# Altium schematic sheet ESP32_CAN_V2-main.SchDoc
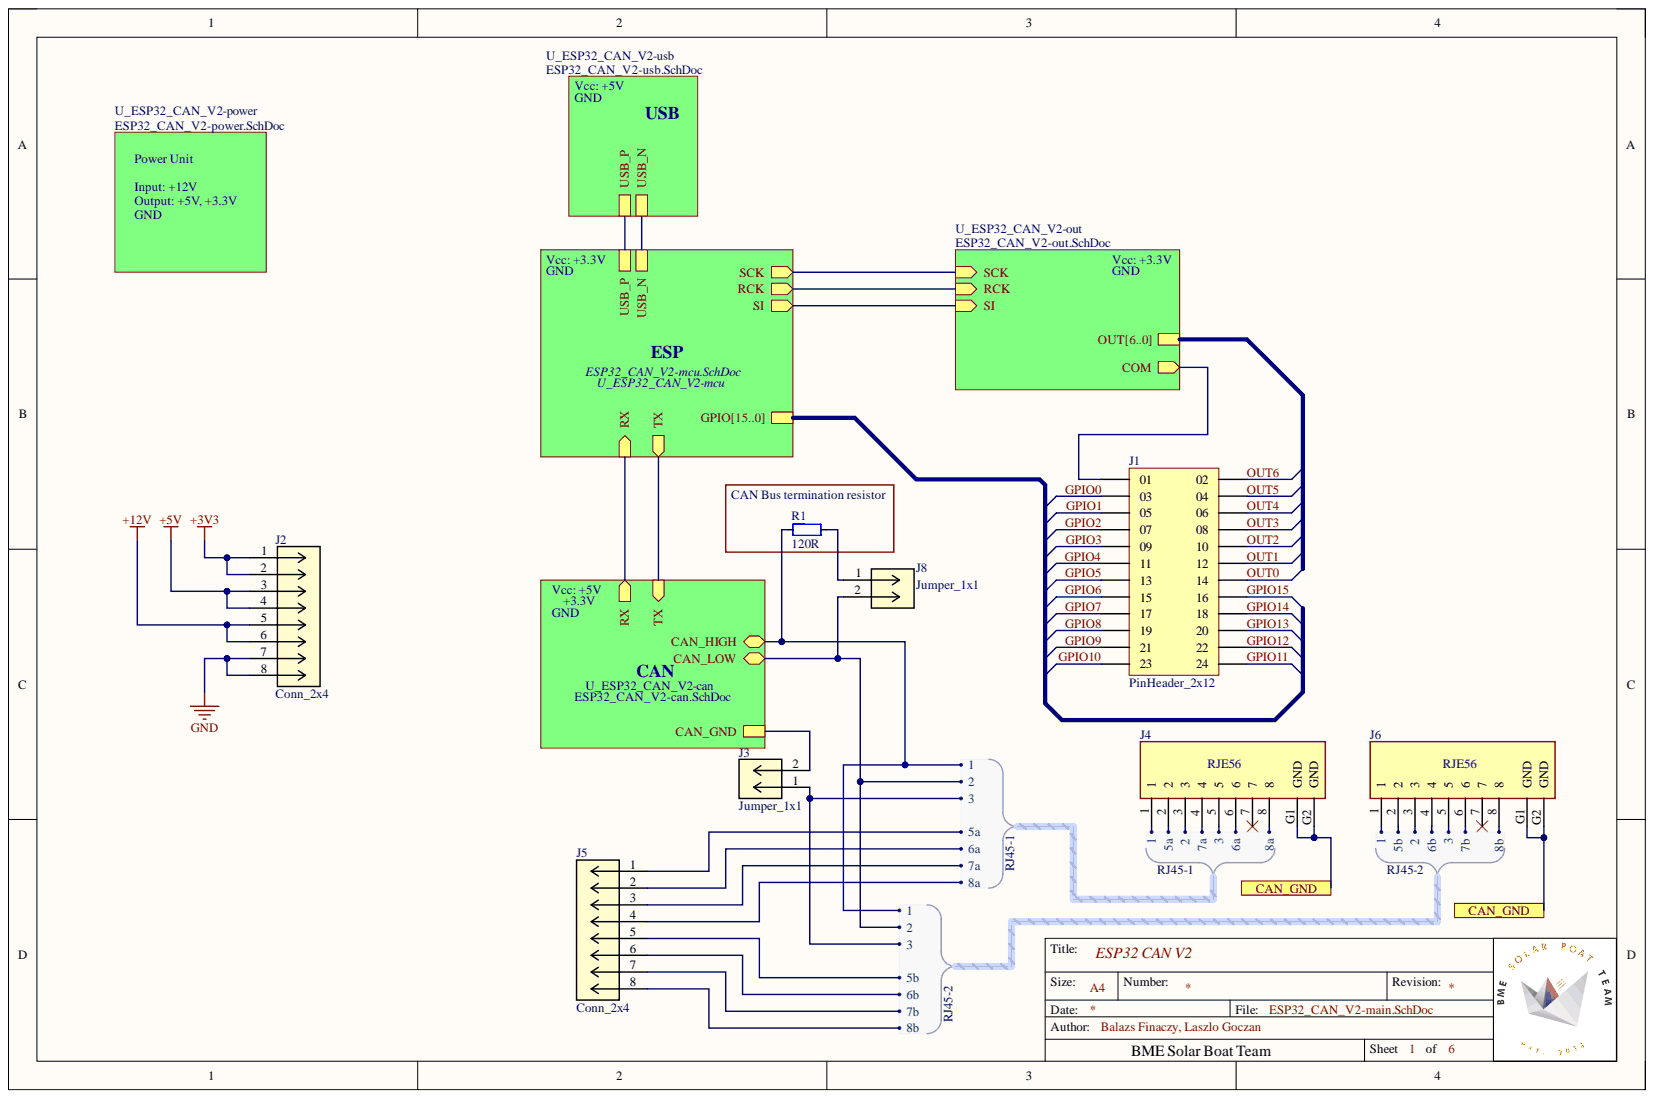
[diagram p0: ESP32_CAN_V2-main.SchDoc]

## Parts
J1  PinHeader_2x12  [AMPHENOL_10129381-924003BLF]
J2  Conn_2x4  [CONN_10129381-X08002XLF_AMP]
J3  Jumper_1x1  [CON2_1X2_TU_TSW]
J4  RJE56  [AMPHENOL_RJE561881410]
J5  Conn_2x4  [CONN_10129381-X08002XLF_AMP]
J6  RJE56  [AMPHENOL_RJE561881410]
J8  Jumper_1x1  [CON2_1X2_TU_TSW]
R1  120R  [R0603]

## Ports
CAN_GND

## Nets
+3V3: J2.1 J2.2
+5V: J2.3 J2.4
+12V: J2.5 J2.6
GND: J2.7 J2.8
CAN_GND: J4.G1(GND) J4.G2(GND) J6.G1(GND) J6.G2(GND) ; port CAN_GND
GPIO0: J1.03  [also labelled ]
GPIO1: J1.05  [also labelled ]
GPIO2: J1.07  [also labelled ]
GPIO3: J1.09  [also labelled ]
GPIO4: J1.11  [also labelled ]
GPIO5: J1.13  [also labelled ]
GPIO6: J1.15  [also labelled ]
GPIO7: J1.17  [also labelled ]
GPIO8: J1.19  [also labelled ]
GPIO9: J1.21  [also labelled ]
GPIO10: J1.23  [also labelled ]
GPIO11: J1.24  [also labelled ]
GPIO12: J1.22  [also labelled ]
GPIO13: J1.20  [also labelled ]
GPIO14: J1.18  [also labelled ]
GPIO15: J1.16  [also labelled ]
OUT0: J1.14  [also labelled ]
OUT1: J1.12  [also labelled ]
OUT2: J1.10  [also labelled ]
OUT3: J1.08  [also labelled ]
OUT4: J1.06  [also labelled ]
OUT5: J1.04  [also labelled ]
OUT6: J1.02  [also labelled ]
NetJ1_01: J1.01
NetJ3_1: J3.1 J4.5 J6.5
NetJ3_2: J3.2
NetJ4_1: J4.1 J6.1 R1.1
NetJ4_2: J4.2 J5.1
NetJ4_3: J4.3 J6.3 J8.2
NetJ4_4: J4.4 J5.3
NetJ4_6: J4.6 J5.2
NetJ4_7: J4.7
NetJ4_8: J4.8 J5.4
NetJ5_5: J5.5 J6.2
NetJ5_6: J5.6 J6.4
NetJ5_7: J5.7 J6.6
NetJ5_8: J5.8 J6.8
NetJ6_7: J6.7
NetJ8_1: J8.1 R1.2
RCK_1:
RX_1:
SCK_1:
SI_1:
TX_1:
USB_1_N:
USB_1_P:

## Library data of the parts
J1 = PinHeader_2x12: MF: {'manufacturer': u'Amphenol ICC (FCI)'}; MP: 10129381-924003BLF; Availability: Unavailable; Component Kind: Standard; Library Name: ESP32_CAN_V2.SchLib; Package: None; Price: None
J2 J5 = PinHeader_2x4: Manufacturer_Name: Amphenol ICC; Manufacturer_Part_Number: 10129381-908002BLF; Component Kind: Standard; Library Name: ESP32_CAN_V2.SchLib; RefDes: RefDes; Type: Type; Copyright: Copyright (C) 2026 Ultra Librarian. All rights reserved.
J3 J8 = TSW-102-08-T-S: No Description Available; Manufacturer_Name: Samtec Inc; Component Kind: Standard; Library Name: ESP32_CAN_V2.SchLib; Manufacturer_Part_Number: TSW-102-08-T-S; RefDes: RefDes; Type: Type; Copyright: Copyright (C) 2026 Ultra Librarian. All rights reserved.
J4 J6 = RJE561881410: Modular Jack - Right Angle, Input Output Connectors 8P8C, Without Shield .; MF: Amphenol Commercial Products; Reference: RJE56-188-1410; Check_prices: https://www.snapeda.com/parts/RJE561881410/Amphenol/view-part/?ref=eda; Component Kind: Standard; Library Name: ESP32_CAN_V2.SchLib; MP: RJE561881410; Package: None; SnapEDA_Link: https://www.snapeda.com/parts/RJE561881410/Amphenol/view-part/?ref=snap; Supplier: Farnell; Type: RJE56
R1 = R: Component Kind: Standard; Library Name: ESP32_CAN_V2.SchLib
